# Altium schematic sheet XBEE_BREAKOUT.SchDoc(XBEE_BREAKOUT)
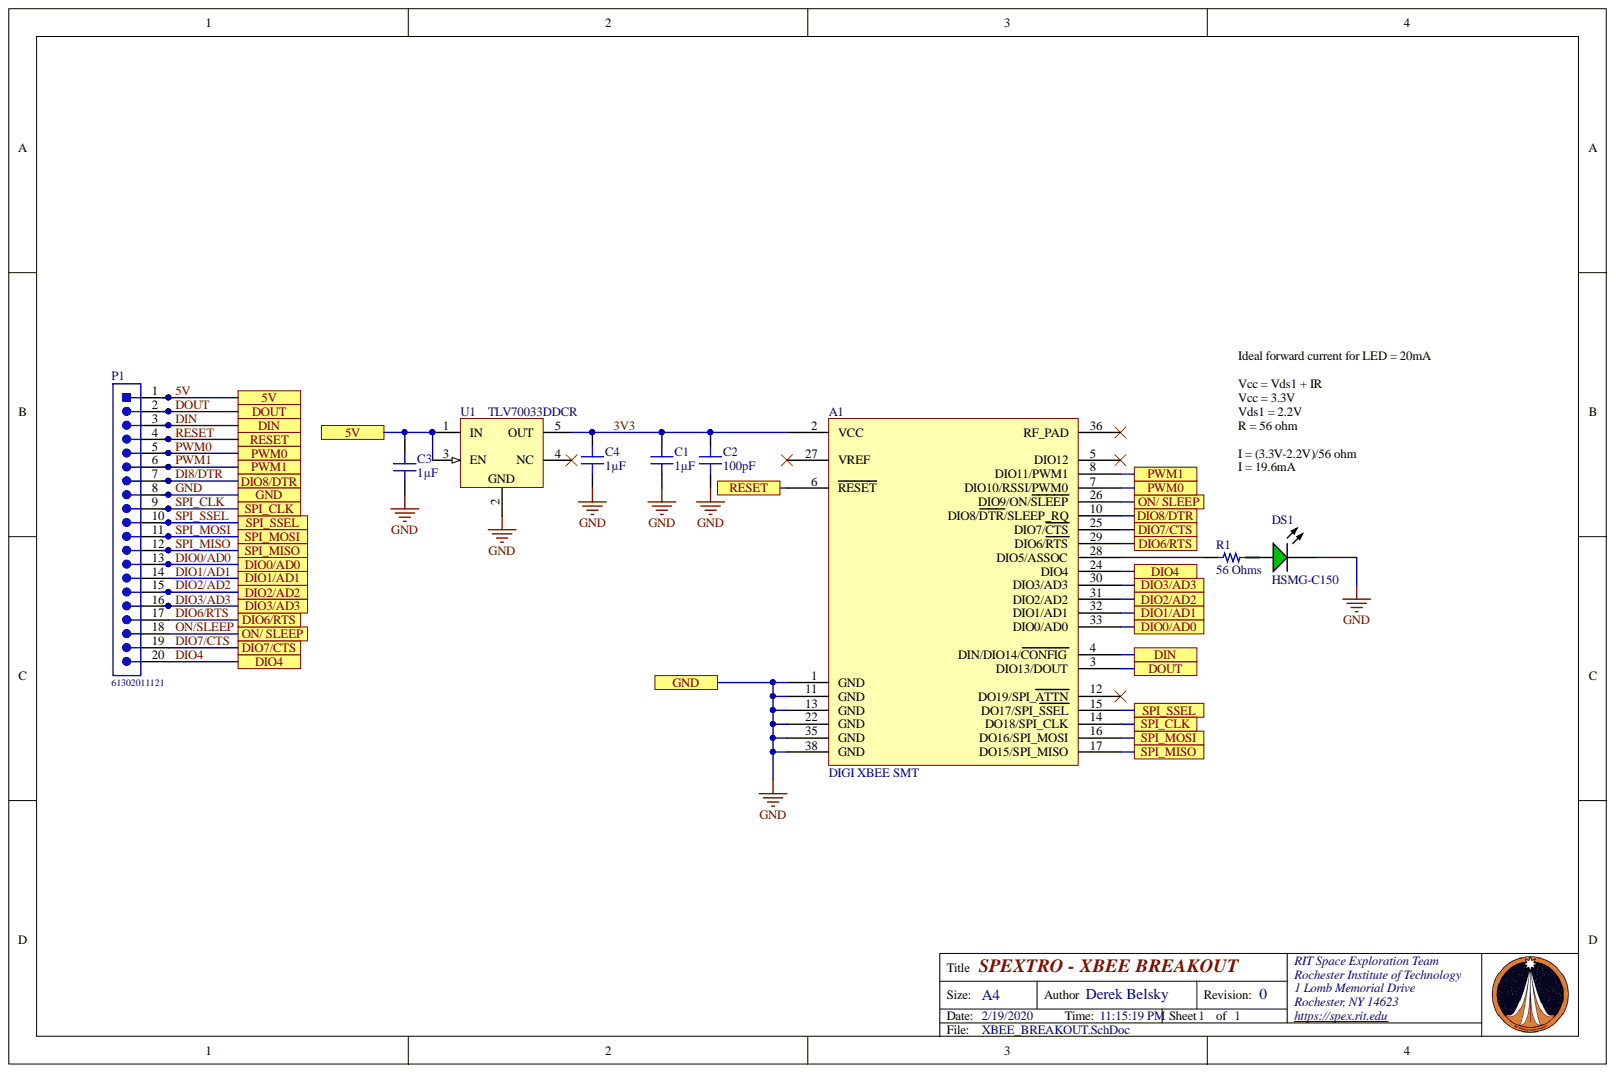
[diagram p0: XBEE_BREAKOUT.SchDoc]

## Parts
A1  DIGI XBEE SMT  [*XCVR_XBP9X-DMUS-001]
C1  1µF  [CAP 0603_1608]
C2  100pF  [CAP 0603_1608]
C3  1µF  [CAP 0603_1608]
C4  1µF  [CAP 0603_1608]
DS1  HSMG-C150  [AVAG-HSMX-C150_V]
P1  61302011121  [61302011121]
R1  56 Ohms  [RES 1206_3216]
U1  TLV70033DDCR  [DDC0005A_M]

## Ports
5V, DIN, DIO0/AD0, DIO1/AD1, DIO2/AD2, DIO3/AD3, DIO4, DIO6/RTS, DIO7/CTS, DIO8/DTR, DOUT, GND, ON/ SLEEP, PWM0, PWM1, RESET, SPI_CLK, SPI_MISO, SPI_MOSI, SPI_SSEL

## Nets
3V3: A1.2(VCC) C1.2 C2.2 C4.2 U1.5(OUT)
5V: C3.2 P1.1 U1.1(IN) U1.3(EN) ; port 5V
DI8/DTR: A1.10(DIO8/DTR/SLEEP_RQ) P1.7 ; port DIO8/DTR
DIN: A1.4(DIN/DIO14/CONFIG) P1.3 ; port DIN
DIO0/AD0: A1.33(DIO0/AD0) P1.13 ; port DIO0/AD0
DIO1/AD1: A1.32(DIO1/AD1) P1.14 ; port DIO1/AD1
DIO2/AD2: A1.31(DIO2/AD2) P1.15 ; port DIO2/AD2
DIO3/AD3: A1.30(DIO3/AD3) P1.16 ; port DIO3/AD3
DIO4: A1.24(DIO4) P1.20 ; port DIO4
DIO6/RTS: A1.29(DIO6/RTS) P1.17 ; port DIO6/RTS
DIO7/CTS: A1.25(DIO7/CTS) P1.19 ; port DIO7/CTS
DOUT: A1.3(DIO13/DOUT) P1.2 ; port DOUT
GND: A1.1(GND) A1.11(GND) A1.13(GND) A1.22(GND) A1.35(GND) A1.38(GND) C1.1 C2.1 C3.1 C4.1 DS1.2 P1.8 U1.2 ; port GND
ON/SLEEP: A1.26(DIO9/ON/SLEEP) P1.18 ; port ON/ SLEEP
PWM0: A1.7(DIO10/RSSI/PWM0) P1.5 ; port PWM0
PWM1: A1.8(DIO11/PWM1) P1.6 ; port PWM1
RESET: A1.6(RESET) P1.4 ; port RESET
SPI_CLK: A1.14(DO18/SPI_CLK) P1.9 ; port SPI_CLK
SPI_MISO: A1.17(DO15/SPI_MISO) P1.12 ; port SPI_MISO
SPI_MOSI: A1.16(DO16/SPI_MOSI) P1.11 ; port SPI_MOSI
SPI_SSEL: A1.15(DO17/SPI_SSEL) P1.10 ; port SPI_SSEL
NetA1_28: A1.28(DIO5/ASSOC) R1.1
NetDS1_1: DS1.1 R1.2

Not connected: A1 5(DIO12) 12(DO19/SPI_ATTN) 27(VREF) 36(RF_PAD); U1 4(NC)

## Library data of the parts
C1 C3 C4 = CC0603KRX7R6BB105: CAP CER 1UF 10V X7R 0603; Manufacturer: Yageo; Tolerance: ±10%; Database Table Name: Capacitors - Ceramic - 0603; Voltage - Rated: 10V; Value: 1µF; Thickness (Max): 0.035" (0.90mm); Temperature Coefficient: X7R; Supplier Part Number 1: 311-1796-1-ND; Supplier 1: DigiKey; Size / Dimension: 0.063" L x 0.031" W (1.60mm x 0.80mm); Series: CC; Price: 0.02; Part Status: Active; Part Number: CC0603KRX7R6BB105; PartId: 2290; Packaging: TapeAndReel; Package / Case: 0603 (1608 Metric); Operating Temperature: -55°C ~ 125°C; Mounting Type: Surface Mount, MLCC; Minimum Order: 1; Lifecycle Status: Active; Library Name: Celestial Altium Library - altium_library.DbLib; LastUpdated: 1/21/2020 12:02:09 AM; Device Package: 0603 (1608 Metric); ComponentLink2URL: http://digikey.com/product-detail/en/yageo/CC0603KRX7R6BB105/311-1796-1-ND/5195698; ComponentLink2Description: DigiKey Link; ComponentLink1URL: https://www.yageo.com/upload/media/product/productsearch/datasheet/mlcc/UPY-GPHC_X7R_6.3V-to-50V_18.pdf; ComponentLink1Description: Datasheet; Component Kind: Standard; Capacitance: 1µF; Applications: General Purpose
C2 = 06031A101FAT2A: CAP CER 100PF 100V C0G/NP0 0603; Manufacturer: AVX Corporation; Tolerance: ±1%; Temperature Coefficient: C0G, NP0; Database Table Name: Capacitors - Ceramic - 0603; Voltage - Rated: 100V; Value: 100pF; Thickness (Max): 0.035" (0.90mm); Supplier Part Number 1: 478-3671-1-ND; Supplier 1: DigiKey; Size / Dimension: 0.063" L x 0.032" W (1.60mm x 0.81mm); Series: -; Price: 0.10; Part Status: Active; Part Number: 06031A101FAT2A; PartId: 2633; Packaging: TapeAndReel; Package / Case: 0603 (1608 Metric); Operating Temperature: -55°C ~ 125°C; Mounting Type: Surface Mount, MLCC; Minimum Order: 1; Lifecycle Status: Active; Library Name: Celestial Altium Library - altium_library.DbLib; LastUpdated: 1/21/2020 12:02:09 AM; Device Package: 0603 (1608 Metric); ComponentLink2URL: http://digikey.com/product-detail/en/avx-corporation/06031A101FAT2A/478-3671-1-ND/1116369; ComponentLink2Description: DigiKey Link; ComponentLink1URL: http://datasheets.avx.com/C0GNP0-Dielectric.pdf; ComponentLink1Description: Datasheet; Component Kind: Standard; Capacitance: 100pF; Applications: General Purpose
DS1 = CMP-2000-05061-1: Chip LED, Green, 65 mW, 25 mA, -40 to 85 degC, 2-Pin SMD, RoHS, Tape and Reel; Manufacturer: Avago; Wavelength Typ: 572nm; Voltage Vf Typ: 2.2V; Viewing Angle: 170deg; SVHC: NoSVHC(16-Jun-2014); Reverse Protection Voltage: 5V; Peak Wavelength: 570nm; Opto Mounting Orientation: Top; No. of Pins: 2; Material: GaP; Luminous Intensity Typ: 15mcd; Luminous Intensity @ If Min: 4.5mcd; Light Output Orientation: TopEmitting; Lens Shape: Rectangular; LED Type: Standard; Forward Voltage VF Max: 2.6V; Forward Current If Max: 20mA; External Width: 1.6mm; External Length / Height: 3.2mm; External Depth: 1.1mm; Bulb Size: 1.6mmx2mm; Voltage Vf Min: 2.2V; Storage Temperature Min: -40degC; Storage Temperature Max: 85degC; PIV Max: 5V; Packaging: CutTape; Package Reference: HSMX-C150; Package Description: 2-Pin Surface Mount Device, Body 3.2 x 1.6 mm; Opto Case Style: SMD; Operating Temperature Range: -30degCto+85degC; Operating Temperature Min: -30degC; Operating Temperature Max: 85degC; MSL: MSL2A-4weeks; Mounting Type: SMD; Manufacturer URL: http://www.avagotech.com/; Luminous Intensity: 15mcd; Library Name: Altium Content Vault; LED Mounting: SMD; LED Colour: Green; Forward Voltage: 2.2V; Forward Current If: 20mA; Dominant Wavelength: 572nm; Datasheet Version: Mar-12; Datasheet URL: https://datasheet.ciiva.com/11774/0900766b804a6539-11774272.pdf; Component Kind: Standard
P1 = CMP-1502-01102-1: THT Vertical Pin Header WR-PHD, Pitch 2.54 mm, Single Row, 20 pins; Manufacturer: Wurth Elektronik; Working Voltage: 250VAC; Withstanding Voltage: 500VAC; Rated Current: 3A; Pitch: 2.54mm; Pin Number: 20; Gender: Male; Contact Resistance: 20mOhm; Row Number: Single; Part Number: 61302011121; Packaging: Bag; Package Reference: 61302011121; Orientation: Vertical; Operating Temperature: -40to105degC; Mounting Technology: THT; Manufacturer URL: http://www.we-online.de; Library Name: Altium Content Vault; Datasheet URL: https://katalog.we-online.de/em/datasheet/6130xx11121.pdf; Component Kind: Standard; Publisher: WurthElektronik
R1 = CR1206-FX-56R0ELF: RES SMD 56 OHM 1% 1/4W 1206; Manufacturer: Bourns Inc.; Temperature Coefficient: ±100ppm/°C; Power (Watts): 0.25W, 1/4W; Database Table Name: Resistors - Surface Mount - 1206; Value: 56 Ohms; Tolerance: ±1%; Supplier Part Number 1: CR1206-FX-56R0ELFCT-ND; Supplier Device Package: 1206; Supplier 1: DigiKey; Size / Dimension: 0.126" L x 0.063" W (3.20mm x 1.60mm); Series: CR1206; Resistance: 56 Ohms; Price: 0.01; Part Status: Active; Part Number: CR1206-FX-56R0ELF; PartId: 26887; Packaging: TapeAndReel; Package / Case: 1206 (3216 Metric); Operating Temperature: -55°C ~ 155°C; Number of Terminations: 2; Minimum Order: 1; Lifecycle Status: Active; Library Name: Celestial Altium Library - altium_library.DbLib; LastUpdated: 1/21/2020 1:08:34 AM; Height - Seated (Max): 0.030" (0.75mm); Features: Moisture Resistant; Device Package: 1206 (3216 Metric); Composition: Thick Film; ComponentLink2URL: http://digikey.com/product-detail/en/bourns-inc/CR1206-FX-56R0ELF/CR1206-FX-56R0ELFCT-ND/3767738; ComponentLink2Description: DigiKey Link; ComponentLink1URL: https://www.bourns.com/docs/Product-Datasheets/CHPREZTR.pdf; ComponentLink1Description: Datasheet; Component Kind: Standard
U1 = CMP-0394-01056-2: Single Output LDO, 200 mA, Fixed 3.3 V Output, 2 to 5.5 V Input, with Low IQ, 5-pin SOT (DDC), -40 to 125 degC, Green (RoHS & no Sb/Br); Manufacturer: Texas Instruments; Vout Adj(Min)(V): 3.3; Vin(Min)(V): 2; Vin(Max)(V): 5.5; Vdo(Typ)(mV): 175; SubFamily: Single Channel LDO; Iq(Typ)(mA): 0.03; Iout(Max)(A): 0.2; Vout Adj(Max)(V): 3.3; PartNumber: TLV70033DDCR; PackageVersion: 4204403-2/E; PackageReference: DDC0005A; PackageDescription: 5-Pin Plastic Small Outline, Body 2.9 x 1.6 mm, 0.95 mm Pitch; Mounting Technology: Surface Mount; Library Name: Altium Content Vault; Fixed Output Options(V): 3.3; Eco-Plan: Green (RoHS & no Sb/Br); DatasheetVersion: SLVSA00D; ComponentLink3URL: http://www.ti.com/litv/pdf/mpds123d; ComponentLink3Description: Package Specification; ComponentLink2URL: http://www.ti.com/general/docs/lit/getliterature.tsp?genericPartNumber=TLV70033&fileType=pdf; ComponentLink2Description: Datasheet; ComponentLink1URL: http://www.ti.com/; ComponentLink1Description: Manufacturer URL; Component Kind: Standard; Code_JEDEC: MO-193; Accuracy(%): 2
A1 = DIGI XBEE SMT: Library Name: SCH - RF - MODULE - DIGI XBEE SMT.SCHLIB; Component Kind: Standard
